# Altium schematic sheet MODULE BASE.SchDoc
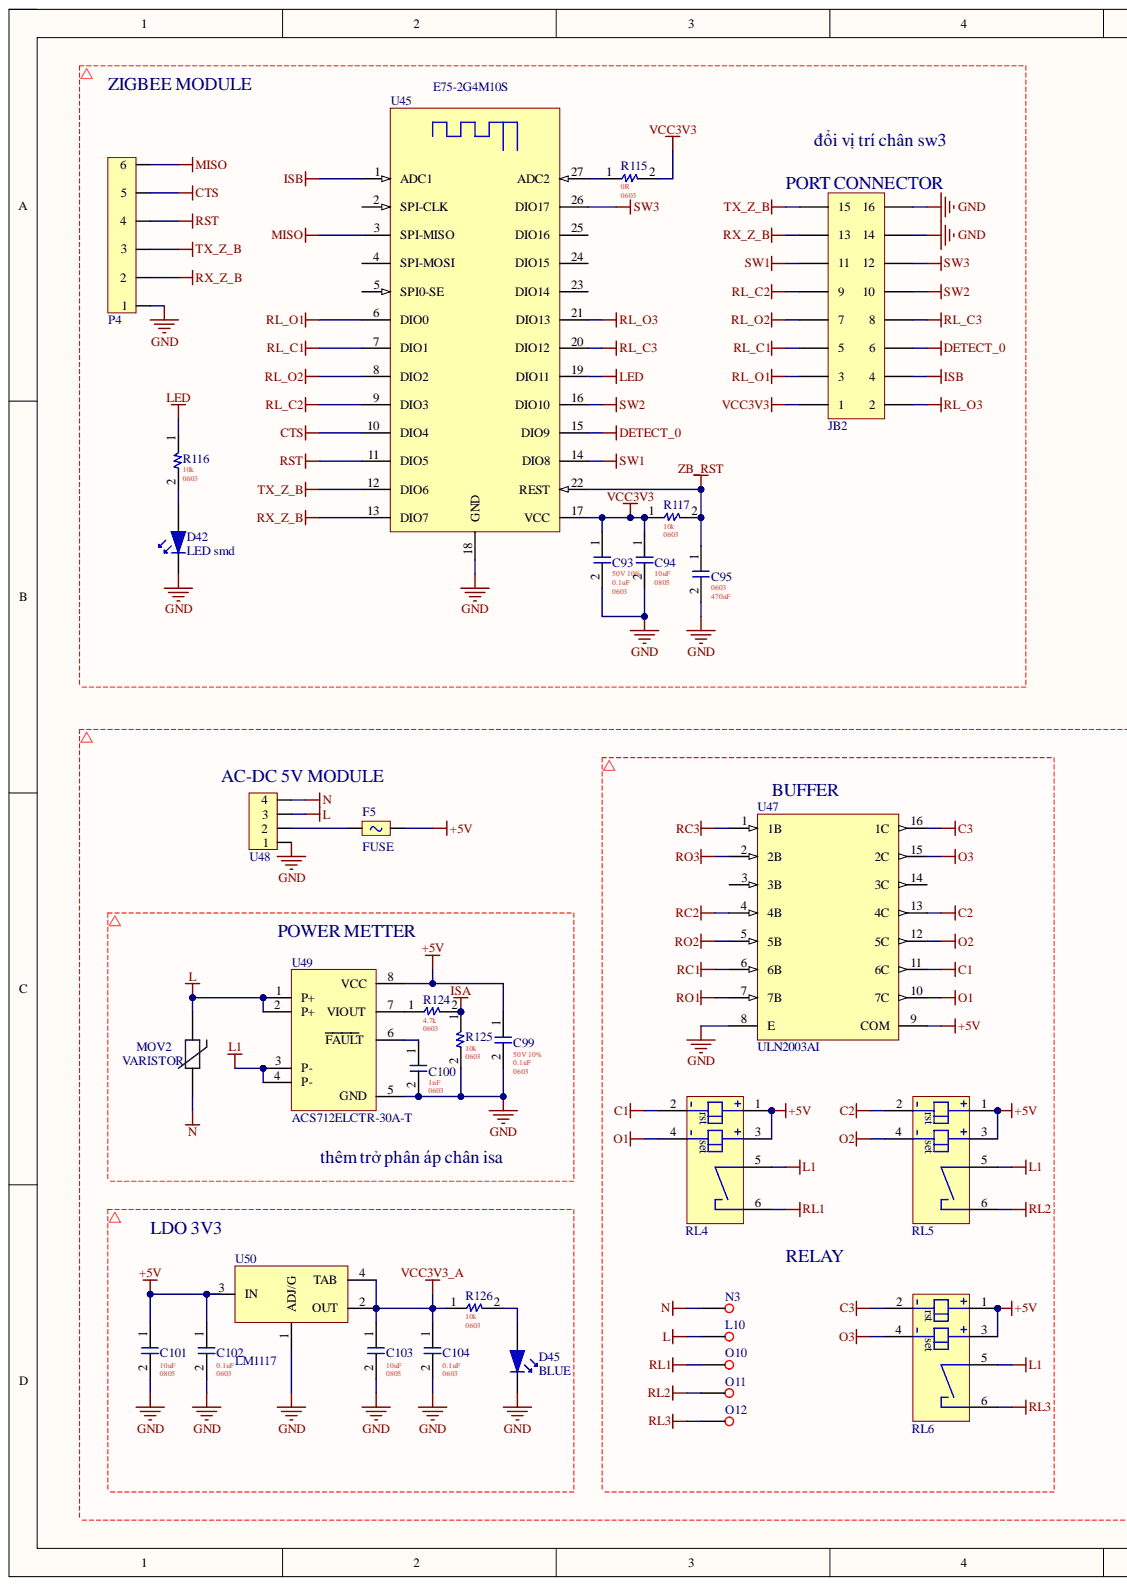
[diagram p0: MODULE BASE.SchDoc, left]
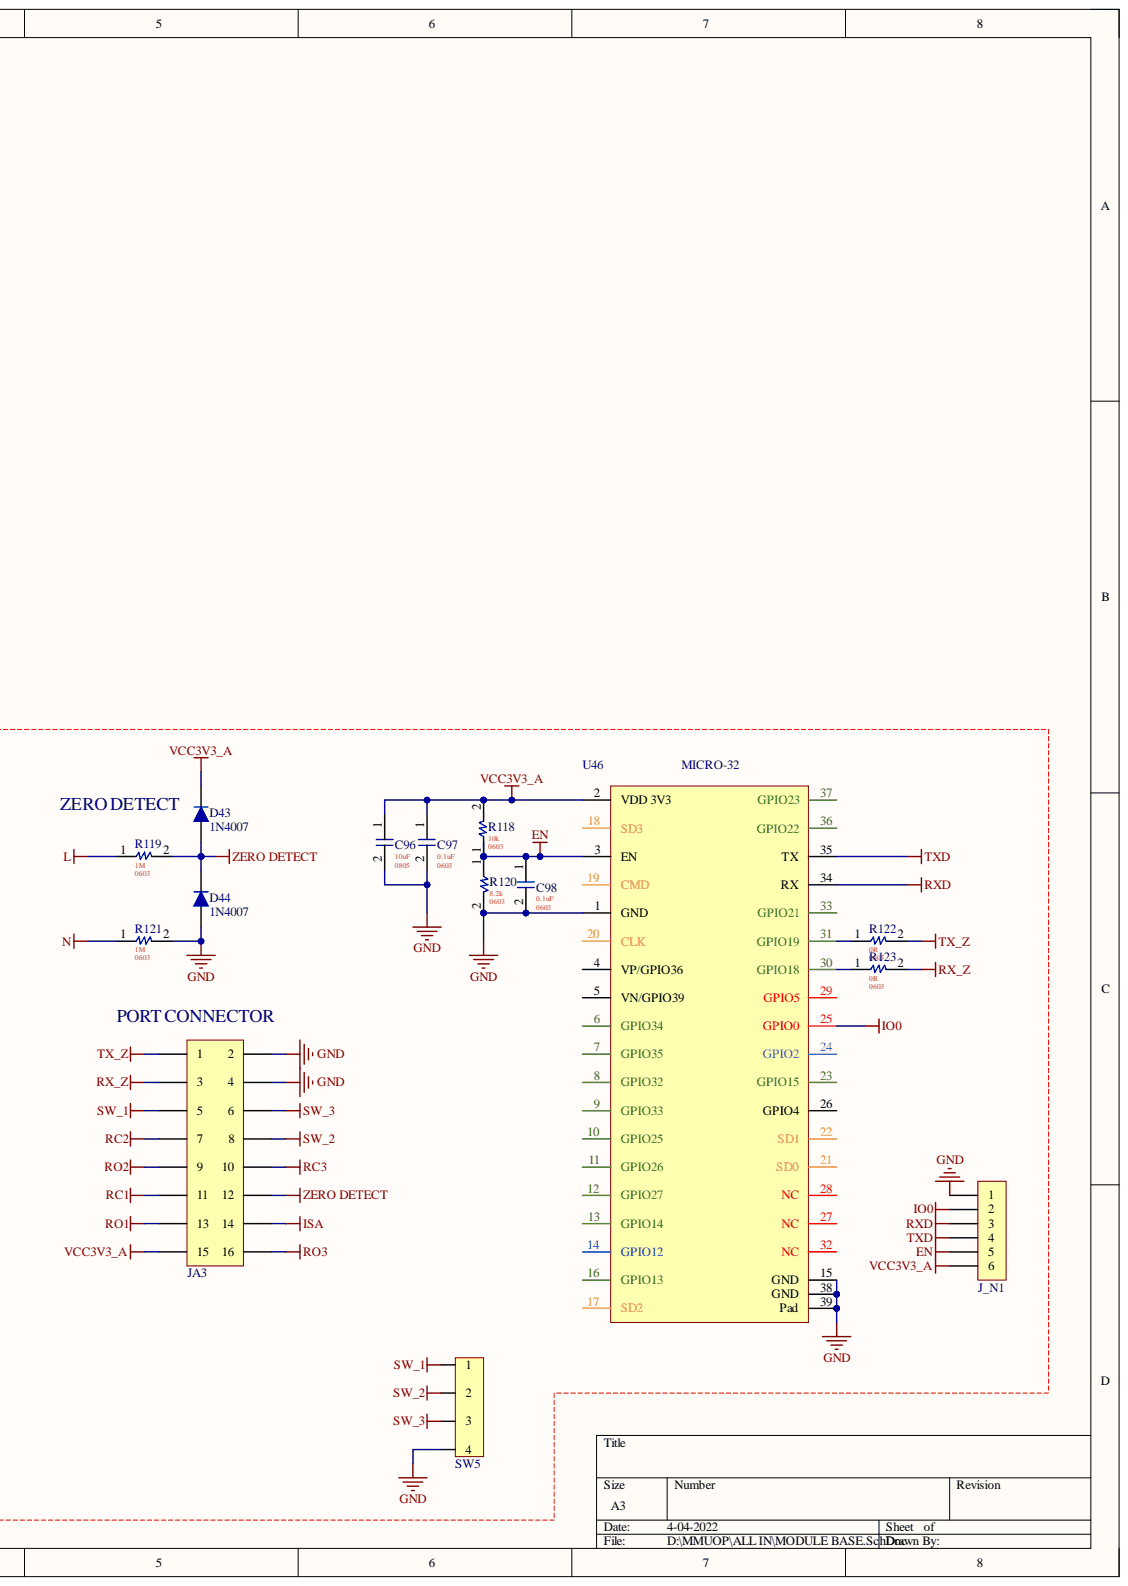
[diagram p1: MODULE BASE.SchDoc, right]

## Parts
C93  0.1uF  FP: 0603  VOLTAGE + TOLE: 50V 10%  [0603 C]
C94  10uF  FP: 0805  [0805 C]
C95  470nF  FP: 0603  [0603 C]
C96  10uF  FP: 0805  [0805 C]
C97  0.1uF  FP: 0603  [0603 C]
C98  0.1uF  FP: 0603  [0603 C]
C99  0.1uF  FP: 0603  VOLTAGE + TOLE: 50V 10%  [0603 C]
C100  1nF  FP: 0603  [0603 C]
C101  10uF  FP: 0805  [0805 C]
C102  0.1uF  FP: 0603  [0603 C]
C103  10uF  FP: 0805  [0805 C]
C104  0.1uF  FP: 0603  [0603 C]
D42  LED smd  [LED0603_1608]
D43  1N4007  [SOD-123]
D44  1N4007  [SOD-123]
D45  BLUE  [LED0603_1608]
F5  FUSE  [1206 - fuse]
J_N1  P6  [P6 - 1.27MM]  (value not drawn)
JA3  rao doi  [P2X8 - 2.0mm - female - SMD]  (value not drawn)
JB2  rao doi  [P2X8 - 2.0mm - SMD]  (value not drawn)
L10  P1  [P1 - BRASS TERMINAL]  (value not drawn)
MOV2  VARISTOR  [MOV]
N3  P1  [P1 - BRASS TERMINAL]  (value not drawn)
O10  P1  [P1 - BRASS TERMINAL]  (value not drawn)
O11  P1  [P1 - BRASS TERMINAL]  (value not drawn)
O12  P1  [P1 - BRASS TERMINAL]  (value not drawn)
P4  P6  [P6 - 1.27MM]  (value not drawn)
R115  0R  FP: 0603  [0603 R]
R116  10k  FP: 0603  [0603 R]
R117  10k  FP: 0603  [0603 R]
R118  10k  FP: 0603  [0603 R]
R119  1M  FP: 0603  [0603 R]
R120  8.2k  FP: 0603  [0603 R]
R121  1M  FP: 0603  [0603 R]
R122  0R  [0603 R]
R123  0R  FP: 0603  [0603 R]
R124  4.7k  FP: 0603  [R 0603]
R125  10k  FP: 0603  [R 0603]
R126  10k  FP: 0603  [0603 R]
RL4  RELAY CHOT  [HFE60]  (value not drawn)
RL5  RELAY CHOT  [HFE60]  (value not drawn)
RL6  RELAY CHOT  [HFE60]  (value not drawn)
SW5  P4  [P4 2.54 RA header]  (value not drawn)
U45  E75-2G4M10S  [ebyte - E75-2G4M10S]
U46  MICRO-32  [ESP MICRO 32]
U47  ULN2003AI  [SOIC 16]
U48  AC-DC 5V  [AC-DC 5V K4H24]
U49  ACS712ELCTR-30A-T  [SOIC 8]
U50  LM1117  [SOT23-4]

## Nets
+5V: C99.1 C101.1 C102.1 F5.2 RL4.1 RL4.3 RL5.1 RL5.3 RL6.1 RL6.3 U47.9(COM) U49.8(VCC) U50.3(IN)
C1: RL4.2 U47.11(6C)
C2: RL5.2 U47.13(4C)
C3: RL6.2 U47.16(1C)
CTS: P4.5 U45.10(DIO4)
DETECT_0: JB2.6 U45.15(DIO9)
EN: C98.1 J_N1.5 R118.1 R120.1 U46.3(EN)
GND: C93.2 C94.2 C95.2 C96.2 C97.2 C98.2 C99.2 C100.2 C101.2 C102.2 C103.2 C104.2 D42.2 D44.1 D45.2 J_N1.1 JA3.2 JA3.4 JB2.14 JB2.16 P4.1 R120.2 R121.2 R125.2 SW5.4 U45.18(GND) U46.1(GND) U46.15(GND) U46.38(GND) U46.39(Pad) U47.8(E) U48.1 U49.5(GND) U50.1(ADJ/G)
IO0: J_N1.2 U46.25(GPIO0)
ISA: JA3.14 R124.2 R125.1
ISB: JB2.4 U45.1(ADC1)
L: L10.1 MOV2.2 R119.1 U48.3 U49.1(P+) U49.2(P+)
L1: RL4.5 RL5.5 RL6.5 U49.3(P-) U49.4(P-)
LED: R116.1 U45.19(DIO11)
MISO: P4.6 U45.3(SPI-MISO)
N: MOV2.1 N3.1 R121.1 U48.4
O1: RL4.4 U47.10(7C)
O2: RL5.4 U47.12(5C)
O3: RL6.4 U47.15(2C)
RC1: JA3.11 U47.6(6B)
RC2: JA3.7 U47.4(4B)
RC3: JA3.10 U47.1(1B)
RL1: O10.1 RL4.6
RL2: O11.1 RL5.6
RL3: O12.1 RL6.6
RL_C1: JB2.5 U45.7(DIO1)
RL_C2: JB2.9 U45.9(DIO3)
RL_C3: JB2.8 U45.20(DIO12)
RL_O1: JB2.3 U45.6(DIO0)
RL_O2: JB2.7 U45.8(DIO2)
RL_O3: JB2.2 U45.21(DIO13)
RO1: JA3.13 U47.7(7B)
RO2: JA3.9 U47.5(5B)
RO3: JA3.16 U47.2(2B)
RST: P4.4 U45.11(DIO5)
RX_Z: JA3.3 R123.2
RX_Z_B: JB2.13 P4.2 U45.13(DIO7)
RXD: J_N1.3 U46.34(RX)
SW1: JB2.11 U45.14(DIO8)
SW2: JB2.10 U45.16(DIO10)
SW3: JB2.12 U45.26(DIO17)
SW_1: JA3.5 SW5.1
SW_2: JA3.8 SW5.2
SW_3: JA3.6 SW5.3
TX_Z: JA3.1 R122.2
TX_Z_B: JB2.15 P4.3 U45.12(DIO6)
TXD: J_N1.4 U46.35(TX)
VCC3V3: C93.1 C94.1 JB2.1 R115.2 R117.1 U45.17(VCC)
VCC3V3_A: C96.1 C97.1 C103.1 C104.1 D43.2 J_N1.6 JA3.15 R118.2 R126.1 U46.2(VDD_3V3) U50.2(OUT) U50.4(TAB)
ZB_RST: C95.1 R117.2 U45.22(REST)
ZERO DETECT: D43.1 D44.2 JA3.12 R119.2
NetC100_1: C100.1 U49.6(FAULT)
NetD42_1: D42.1 R116.2
NetD45_1: D45.1 R126.2
NetF5_1: F5.1 U48.2
NetR115_1: R115.1 U45.27(ADC2)
NetR122_1: R122.1 U46.31(GPIO19)
NetR123_1: R123.1 U46.30(GPIO18)
NetR124_1: R124.1 U49.7(VIOUT)

Not connected: U45 2(SPI-CLK) 4(SPI-MOSI) 5(SPI0-SE) 23(DIO14) 24(DIO15) 25(DIO16); U46 4(VP/GPIO36) 5(VN/GPIO39) 6(GPIO34) 7(GPIO35) 8(GPIO32) 9(GPIO33) 10(GPIO25) 11(GPIO26) 12(GPIO27) 13(GPIO14) 14(GPIO12) 16(GPIO13) 17(SD2) 18(SD3) 19(CMD) 20(CLK) 21(SD0) 22(SD1) 23(GPIO15) 24(GPIO2) 26(GPIO4) 27(NC) 28(NC) 29(GPIO5) 32(NC) 33(GPIO21) 36(GPIO22) 37(GPIO23); U47 3(3B) 14(3C)

## Library data of the parts
C93 C99 = 0603 0.1uF CER: CAP CER 0.1uF 50V 10% 0603 SMD; Component Kind: Standard; ComponentLink1Description: New link; DATE: 04-Mar-21; Library Name: Capacitor.SchLib; PACKAGE: SMD; VALUE: 0.1uF; CREATOR: MMUOP
C94 C96 C101 C103 = 0805 10uF CER: CAP CER 10uF 50V 10% 0805 SMD; VOLTAGE + TOLE: 50V 10%; Component Kind: Standard; ComponentLink1Description: New link; DATE: 04-Mar-21; Library Name: Capacitor.SchLib; PACKAGE: SMD; VALUE: 10uF; CREATOR: MMUOP
C95 = 0603 470nF CER: CAP CER 470nF 50V 10% 0603 SMD; VOLTAGE + TOLE: 50V 10%; Component Kind: Standard; ComponentLink1Description: New link; DATE: 04-Mar-21; Library Name: Capacitor.SchLib; PACKAGE: SMD; VALUE: 470nF; CREATOR: MMUOP
C97 C98 C102 C104 = 0603 0.1uF CER: CAP CER 0.1uF 50V 10% 0603 SMD; VOLTAGE + TOLE: 50V 10%; Component Kind: Standard; ComponentLink1Description: New link; DATE: 04-Mar-21; Library Name: Capacitor.SchLib; PACKAGE: SMD; VALUE: 0.1uF; CREATOR: MMUOP
C100 = 0603 1nF CER: CAP CER 1nF 50V 10% 0603 SMD; VOLTAGE + TOLE: 50V 10%; Component Kind: Standard; ComponentLink1Description: New link; DATE: 04-Mar-21; Library Name: Capacitor.SchLib; PACKAGE: SMD; VALUE: 1nF; CREATOR: MMUOP
D43 D44 = Diode schottky: Component Kind: Standard; Library Name: Kienlib.SchLib
D45 = LED smd: Component Kind: Standard; Library Name: Kienlib.SchLib
JA3 JB2 = P2x8: Component Kind: Standard; Library Name: Kienlib.SchLib
R115 R123 = 0603 0R 1%: 0R 1% 0603 SMD; Component Kind: Standard; ComponentLink1Description: New link; DATE: 04-Mar-21; Library Name: Res.SchLib; PACKAGE: SMD; VALUE: 0R; VOLTAGE + TOLE: 1%; CREATOR: MMUOP
R116-R118 R125 R126 = 0603 10k 1%: 10k 1% 0603 SMD; PN: C98220; Component Kind: Standard; ComponentLink1Description: New link; DATE: 04-Mar-21; Library Name: Res.SchLib; PACKAGE: SMD; VALUE: 10k; VOLTAGE + TOLE: 1%; CREATOR: MMUOP
R119 R121 = 0603 1M 1%: 1M 1% 0603 SMD; Component Kind: Standard; ComponentLink1Description: New link; DATE: 04-Mar-21; Library Name: Res.SchLib; PACKAGE: SMD; VALUE: 1M; VOLTAGE + TOLE: 1%; CREATOR: MMUOP
R120 = 0603 8.2k 1%: 8.2k 1% 0603 SMD; Component Kind: Standard; ComponentLink1Description: New link; DATE: 04-Mar-21; Library Name: Res.SchLib; PACKAGE: SMD; VALUE: 8.2k; VOLTAGE + TOLE: 1%; CREATOR: MMUOP
R122 = 0603 0R 1%: 0R 1% 0603 SMD; FP: 0603; Component Kind: Standard; ComponentLink1Description: New link; DATE: 04-Mar-21; Library Name: Res.SchLib; PACKAGE: SMD; VALUE: 0R; VOLTAGE + TOLE: 1%; CREATOR: MMUOP
R124 = 0603 4.7k 1%: 4.7k 1% 0603 SMD; Component Kind: Standard; ComponentLink1Description: New link; DATE: 04-Mar-21; Library Name: Res.SchLib; PACKAGE: SMD; VALUE: 4.7k; VOLTAGE + TOLE: 1%; CREATOR: MMUOP
U45 = ebyte - E75-2G4M10S: Component Kind: Standard; Description: E75-2G4M10S; Library Name: Kienlib.SchLib
U46 = ESP MICRO-32_FIX: Component Kind: Standard; Description: MICRO-32; Library Name: Kienlib.SchLib
U47 = ULN2003AI: TRANS 7NPN DARL 50V 0.5A 16SO; Component Kind: Standard; Supplier 1: Digi-Key; Supplier Part Number 1: 296-29404-1-ND; Library Name: Kienlib.SchLib
U48 = P4: Component Kind: Standard; Library Name: Kienlib.SchLib
U49 = ACS71240: ACS712ELCTR-20A  SOIC 8; Component Kind: Standard; ComponentLink1Description: New link; ComponentLink1URL: https://banlinhkiendientu.vn/acs712t/; Library Name: Kienlib.SchLib
D42 = LED smd: Component Kind: Standard; Library Name: Kienlib.SchLib
F5 = FUSE: Component Kind: Standard; Library Name: Kienlib.SchLib
J_N1 P4 = P6: Component Kind: Standard; Library Name: Kienlib.SchLib
L10 N3 O10-O12 = P1: Component Kind: Standard; Library Name: Kienlib.SchLib
MOV2 = VARISTOR: Component Kind: Standard; Library Name: Kienlib.SchLib
RL4-RL6 = RELAY CHOT: Component Kind: Standard; Library Name: Kienlib.SchLib
SW5 = P4: Component Kind: Standard; Library Name: Kienlib.SchLib
U50 = LM1117: Component Kind: Standard; Library Name: Kienlib.SchLib
